# Altium schematic sheet Xberry 3 Memory and IO.SchDoc("Xberry 3 Memory and IO")
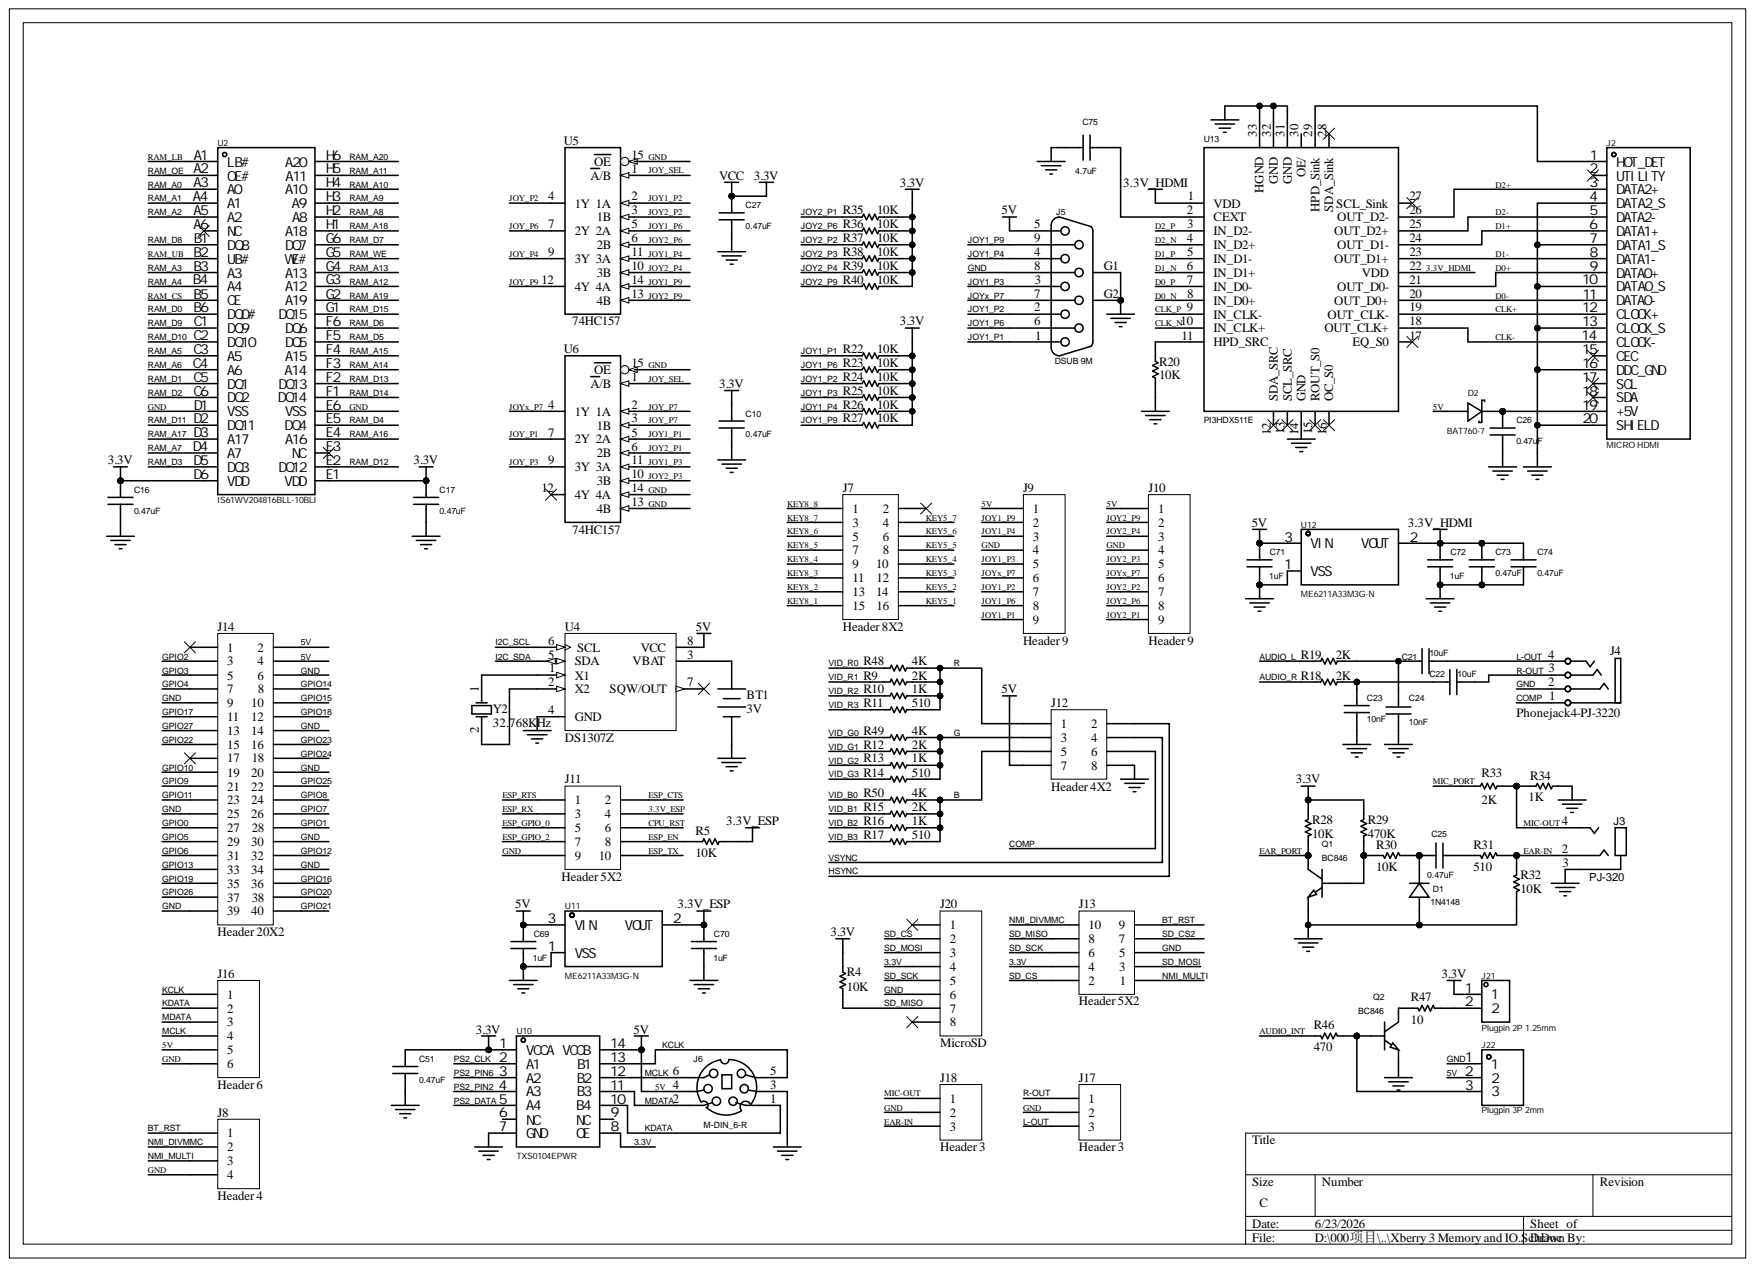
[diagram p0: Xberry 3 Memory and IO.SchDoc]

## Parts
BT1  3V  [BAT-TH_BS-12-A1AJ002-F]
C10  0.47uF  [CAP: 0402]
C16  0.47uF  [CAP: 0402]
C17  0.47uF  [CAP: 0402]
C21  10uF  [CAP: 0603]
C22  10uF  [CAP: 0603]
C23  10nF  [CAP: 0603]
C24  10nF  [CAP: 0603]
C25  0.47uF  [CAP: 0402]
C26  0.47uF  [CAP: 0402]
C27  0.47uF  [CAP: 0402]
C51  0.47uF  [CAP: 0402]
C69  1uF  [CAP: 0603]
C70  1uF  [CAP: 0603]
C71  1uF  [CAP: 0603]
C72  1uF  [CAP: 0603]
C73  0.47uF  [CAP: 0402]
C74  0.47uF  [CAP: 0402]
C75  4.7uF  [CAP: 0402]
D1  1N4148  [DIODE: SOD523]
D2  BAT760-7  [SOD-323_L1.8-W1.3-LS2.5-RD]
J2  MICRO HDMI  Connector Type: HDMI  [HDMI-MICRO_HDMI-101S]
J3  PJ-320  [AUDIO-TH_HOOYA_PJ-320]
J4  Phonejack4-PJ-3220  [AUDIO JACK PJ-3220-5A-C707251]
J5  DSUB 9M  [DB9_MALE]
J6  M-DIN_6-R  [DINC/MIN_TM/6]
J7  Header 8X2  [HDR2X8]
J8  Header 4  [HDR1X4]
J9  Header 9  [HDR1X9]
J10  Header 9  [HDR1X9]
J11  Header 5X2  [HDR2X5]
J12  Header 4X2  [HDR2X4]
J13  Header 5X2  [HDR2X5]
J14  Header 20X2  [HDR2X20]
J16  Header 6  [HDR1X6]
J17  Header 3  [HDR1X3]
J18  Header 3  [HDR1X3]
J20  MicroSD  [SD JLCPCB-C428492]
J21  Plugpin 2P 1.25mm  Number of Pins: 2P  Pitch: 1.25mm  [CONN-TH_0530470210]
J22  Plugpin 3P 2mm  Number of Pins: 3P  Pitch: 2mm  [CONN-TH_A2007WV-3P]
Q1  BC846  [SOT23]
Q2  BC846  [SOT23]
R4  10K  [RES: 0603]
R5  10K  [RES: 0603]
R9  2K  [RES: 0603]
R10  1K  [RES: 0603]
R11  510  [RES: 0603]
R12  2K  [RES: 0603]
R13  1K  [RES: 0603]
R14  510  [RES: 0603]
R15  2K  [RES: 0603]
R16  1K  [RES: 0603]
R17  510  [RES: 0603]
R18  2K  [RES: 0603]
R19  2K  [RES: 0603]
R20  10K  [RES: 0603]
R22  10K  [RES: 0603]
R23  10K  [RES: 0603]
R24  10K  [RES: 0603]
R25  10K  [RES: 0603]
R26  10K  [RES: 0603]
R27  10K  [RES: 0603]
R28  10K  [RES: 0603]
R29  470K  [RES: 0603]
R30  10K  [RES: 0603]
R31  510  [RES: 0603]
R32  10K  [RES: 0603]
R33  2K  [RES: 0603]
R34  1K  [RES: 0603]
R35  10K  [RES: 0603]
R36  10K  [RES: 0603]
R37  10K  [RES: 0603]
R38  10K  [RES: 0603]
R39  10K  [RES: 0603]
R40  10K  [RES: 0603]
R46  470  [RES: 0603]
R47  10  [RES: 0603]
R48  4K  [RES: 0603]
R49  4K  [RES: 0603]
R50  4K  [RES: 0603]
U2  IS61WV204816BLL-10BLI  [TFBGA-48_L8.0-W6.0-R8-C6-P0.75-TL]
U4  DS1307Z  [SOIC8N_N]
U5  74HC157  [SOP65P640-16M]
U6  74HC157  [SOP65P640-16M]
U10  TXS0104EPWR  [TSSOP-14_L5.0-W4.4-P0.65-LS6.4-BL]
U11  ME6211A33M3G-N  [SOT-23-3_L2.9-W1.6-P1.90-LS2.8-BR]
U12  ME6211A33M3G-N  [SOT-23-3_L2.9-W1.6-P1.90-LS2.8-BR]
U13  PI3HDX511E  [TQFN32_3X6]
Y2  32.768KHz  [CYL/D.150/LS.100/.031]

## Nets
3.3V: C10.1 C16.1 C17.1 C27.1 C51.1 J13.4 J20.4 J21.1 R4.2 R22.1 R23.1 R24.1 R25.1 R26.1 R27.1 R28.1 R29.1 R35.1 R36.1 R37.1 R38.1 R39.1 R40.1 U2.D6(VDD) U2.E1(VDD) U5.16 U6.16 U10.1(VCCA) U10.8(OE)
3.3V_ESP: C70.1 J11.4 R5.1 U11.2
3.3V_HDMI: C72.1 C73.1 C74.1 U12.2 U13.1(VDD) U13.22(VDD)
5V: C69.1 C71.1 D2.2 J5.5 J6.4 J9.1 J10.1 J12.7 J14.2 J14.4 J16.5 J22.2 U4.8(VCC) U10.14(VCCB) U11.3 U12.3
AUDIO_INT: R46.2
AUDIO_L: R19.1
AUDIO_R: R18.1
B: J12.5 R15.2 R16.2 R17.2 R50.2
BT_RST: J8.1 J13.9
CLK+: J2.12(CLOCK+) U13.19(OUT_CLK-)
CLK-: J2.14(CLOCK-) U13.18(OUT_CLK+)
CLK_N: U13.10(IN_CLK+)
CLK_P: U13.9(IN_CLK-)
COMP: J4.1 J12.6
CPU_RST: J11.6
D0+: J2.9(DATA0+) U13.21(OUT_D0-)
D0-: J2.11(DATA0-) U13.20(OUT_D0+)
D0_N: U13.8(IN_D0+)
D0_P: U13.7(IN_D0-)
D1+: J2.6(DATA1+) U13.24(OUT_D1-)
D1-: J2.8(DATA1-) U13.23(OUT_D1+)
D1_N: U13.6(IN_D1+)
D1_P: U13.5(IN_D1-)
D2+: J2.3(DATA2+) U13.26(OUT_D2-)
D2-: J2.5(DATA2-) U13.25(OUT_D2+)
D2_N: U13.4(IN_D2+)
D2_P: U13.3(IN_D2-)
EAR-IN: J3.2 J18.3 R31.1 R32.1
EAR_PORT: Q1.3 R28.2
ESP_CTS: J11.2
ESP_EN: J11.8 R5.2
ESP_GPIO_0: J11.5
ESP_GPIO_2: J11.7
ESP_RTS: J11.1
ESP_RX: J11.3
ESP_TX: J11.10
G: J12.3 R12.2 R13.2 R14.2 R49.2
GND: BT1.2 C10.2 C16.2 C17.2 C23.2 C24.1 C26.2 C27.2 C51.2 C69.2 C70.2 C71.2 C72.2 C73.2 C74.2 C75.2 D1.1 J2.4(DATA2_S) J2.7(DATA1_S) J2.10(DATA0_S) J2.13(CLOCK_S) J2.16(DDC_GND) J2.20(SHIELD) J3.3 J4.2 J5.8 J5.G1 J5.G2 J6.3 J6.M1 J6.M2 J6.M3 J8.4 J9.4 J10.4 J11.9 J12.8 J13.5 J14.6 J14.9 J14.14 J14.20 J14.25 J14.30 J14.34 J14.39 J16.6 J17.2 J18.2 J20.6 J22.1 Q1.2 Q2.2 R20.1 R32.2 R34.1 U2.D1(VSS) U2.E6(VSS) U4.4(GND) U5.8 U5.15(OE) U6.8 U6.13(4B) U6.14(4A) U6.15(OE) U10.7(GND) U11.1 U12.1 U13.14(GND) U13.31(GND) U13.32(GND) U13.33(HGND)
GPIO0: J14.27
GPIO1: J14.28
GPIO2: J14.3
GPIO3: J14.5
GPIO4: J14.7
GPIO5: J14.29
GPIO6: J14.31
GPIO7: J14.26
GPIO8: J14.24
GPIO9: J14.21
GPIO10: J14.19
GPIO11: J14.23
GPIO12: J14.32
GPIO13: J14.33
GPIO14: J14.8
GPIO15: J14.10
GPIO16: J14.36
GPIO17: J14.11
GPIO18: J14.12
GPIO19: J14.35
GPIO20: J14.38
GPIO21: J14.40
GPIO22: J14.15
GPIO23: J14.16
GPIO24: J14.18
GPIO25: J14.22
GPIO26: J14.37
GPIO27: J14.13
HSYNC: J12.2
I2C_SCL: U4.6(SCL)
I2C_SDA: U4.5(SDA)
JOY1_P1: J5.1 J9.9 R22.2 U6.5(2A)
JOY1_P2: J5.2 J9.7 R24.2 U5.2(1A)
JOY1_P3: J5.3 J9.5 R25.2 U6.11(3A)
JOY1_P4: J5.4 J9.3 R26.2 U5.11(3A)
JOY1_P6: J5.6 J9.8 R23.2 U5.5(2A)
JOY1_P9: J5.9 J9.2 R27.2 U5.14(4A)
JOY2_P1: J10.9 R35.2 U6.6(2B)
JOY2_P2: J10.7 R37.2 U5.3(1B)
JOY2_P3: J10.5 R38.2 U6.10(3B)
JOY2_P4: J10.3 R39.2 U5.10(3B)
JOY2_P6: J10.8 R36.2 U5.6(2B)
JOY2_P9: J10.2 R40.2 U5.13(4B)
JOY_P1: U6.7(2Y)
JOY_P2: U5.4(1Y)
JOY_P3: U6.9(3Y)
JOY_P4: U5.9(3Y)
JOY_P6: U5.7(2Y)
JOY_P7: U6.2(1A) U6.3(1B)
JOY_P9: U5.12(4Y)
JOY_SEL: U5.1(A/B) U6.1(A/B)
JOYx_P7: J5.7 J9.6 J10.6 U6.4(1Y)
KCLK: J6.5 J16.1 U10.13(B1)
KDATA: J6.1 J16.2 U10.10(B4)
KEY5_1: J7.16
KEY5_2: J7.14
KEY5_3: J7.12
KEY5_4: J7.10
KEY5_5: J7.8
KEY5_6: J7.6
KEY5_7: J7.4
KEY8_1: J7.15
KEY8_2: J7.13
KEY8_3: J7.11
KEY8_4: J7.9
KEY8_5: J7.7
KEY8_6: J7.5
KEY8_7: J7.3
KEY8_8: J7.1
L-OUT: C21.2 J4.4 J17.3
MCLK: J6.6 J16.4 U10.12(B2)
MDATA: J6.2 J16.3 U10.11(B3)
MIC-OUT: J3.4 J18.1 R33.2 R34.2
MIC_PORT: R33.1
NMI_DIVMMC: J8.2 J13.10
NMI_MULTI: J8.3 J13.1
PS2_CLK: U10.2(A1)
PS2_DATA: U10.5(A4)
PS2_PIN2: U10.4(A3)
PS2_PIN6: U10.3(A2)
R: J12.1 R9.2 R10.2 R11.2 R48.2
R-OUT: C22.2 J4.3 J17.1
RAM_A0: U2.A3(A0)
RAM_A1: U2.A4(A1)
RAM_A2: U2.A5(A2)
RAM_A3: U2.B3(A3)
RAM_A4: U2.B4(A4)
RAM_A5: U2.C3(A5)
RAM_A6: U2.C4(A6)
RAM_A7: U2.D4(A7)
RAM_A8: U2.H2(A8)
RAM_A9: U2.H3(A9)
RAM_A10: U2.H4(A10)
RAM_A11: U2.H5(A11)
RAM_A12: U2.G3(A12)
RAM_A13: U2.G4(A13)
RAM_A14: U2.F3(A14)
RAM_A15: U2.F4(A15)
RAM_A16: U2.E4(A16)
RAM_A17: U2.D3(A17)
RAM_A18: U2.H1(A18)
RAM_A19: U2.G2(A19)
RAM_A20: U2.H6(A20)
RAM_CS: U2.B5(CE)
RAM_D0: U2.B6(DQ0#)
RAM_D1: U2.C5(DQ1)
RAM_D2: U2.C6(DQ2)
RAM_D3: U2.D5(DQ3)
RAM_D4: U2.E5(DQ4)
RAM_D5: U2.F5(DQ5)
RAM_D6: U2.F6(DQ6)
RAM_D7: U2.G6(DQ7)
RAM_D8: U2.B1(DQ8)
RAM_D9: U2.C1(DQ9)
RAM_D10: U2.C2(DQ10)
RAM_D11: U2.D2(DQ11)
RAM_D12: U2.E2(DQ12)
RAM_D13: U2.F2(DQ13)
RAM_D14: U2.F1(DQ14)
RAM_D15: U2.G1(DQ15)
RAM_LB: U2.A1(LB#)
RAM_OE: U2.A2(OE#)
RAM_UB: U2.B2(UB#)
RAM_WE: U2.G5(WE#)
SD_CS: J13.2 J20.2
SD_CS2: J13.7
SD_MISO: J13.8 J20.7 R4.1
SD_MOSI: J13.3 J20.3
SD_SCK: J13.6 J20.5
VID_B0: R50.1
VID_B1: R15.1
VID_B2: R16.1
VID_B3: R17.1
VID_G0: R49.1
VID_G1: R12.1
VID_G2: R13.1
VID_G3: R14.1
VID_R0: R48.1
VID_R1: R9.1
VID_R2: R10.1
VID_R3: R11.1
VSYNC: J12.4
NetBT1_1: BT1.1 U4.3(VBAT)
NetC21_1: C21.1 C24.2 R19.2
NetC22_1: C22.1 C23.1 R18.2
NetC25_1: C25.1 D1.2 R30.1
NetC25_2: C25.2 R31.2
NetC26_1: C26.1 D2.1 J2.19(+5V)
NetC75_1: C75.1 U13.2(CEXT)
NetJ2_1: J2.1(HOT_DET) U13.29(HPD_Sink)
NetJ21_2: J21.2 R47.1
NetJ22_3: J22.3 Q2.1 R46.1
NetQ1_1: Q1.1 R29.2 R30.2
NetQ2_3: Q2.3 R47.2
NetR20_2: R20.2 U13.11(HPD_SRC)
NetU4_1: U4.1(X1) Y2.1
NetU4_2: U4.2(X2) Y2.2

Not connected: J2 2(UTILITY) 15(CEC) 17(SCL) 18(SDA); J7 2; J14 1 17; J20 1 8; U2 A6(NC) E3(NC); U4 7(SQW/OUT); U6 12(4Y); U10 6(NC) 9(NC); U13 12(SDA_SRC) 13(SCL_SRC) 15(ROUT_S0) 16(OC_S0) 17(EQ_S0) 27(SCL_Sink) 28(SDA_Sink) 30(OE/)

## Library data of the parts
BT1 = Battery: Multicell Battery; Component Kind: Standard; LatestRevisionDate: 17-Jul-2002; LatestRevisionNote: Re-released for DXP Platform.; Library Name: Miscellaneous Devices.IntLib; PackageDescription: Battery; 2 Leads; PackageReference: BAT-2; Published: 24-Mar-1999; Publisher: Altium Limited
C10 = CAP NP: COMPFIXED: NO; COMPGROUP: 0; COMPHEIGHT: 0.000; COMPLOC: [1695.000,1325.000]; COMPSIDE: BOT; FPLIST: SM/C_0603,SM/C_0805,SM/C_0603_VIA; COMPKEY: NO; COMPLOCKED: NO; Component Kind: Standard; COMPROT: 0; DECOUPLER: NO; MEMORY: NO
C16 C17 C26 C27 C51 C73-C75 = CAP NP: COMPFIXED: NO; COMPGROUP: 0; COMPHEIGHT: 0.000; COMPLOC: [1255.000,1345.000]; COMPROT: 90; COMPSIDE: BOT; FPLIST: SM/C_0805,SM/C_0603; COMPKEY: NO; COMPLOCKED: NO; Component Kind: Standard; DECOUPLER: NO; MEMORY: NO
C21 C22 = CAP NP: COMPFIXED: NO; COMPGROUP: 0; COMPHEIGHT: 0.000; COMPLOC: [250.000,620.000]; COMPROT: 180; COMPSIDE: TOP; FPLIST: SM/C_0805,SM/C_0603_VIA,SM/C_0603,SM/C_0805_VIA; COMPKEY: NO; COMPLOCKED: NO; Component Kind: Standard; DECOUPLER: NO; MEMORY: NO
C23-C25 = CAP NP: COMPFIXED: NO; COMPGROUP: 0; COMPHEIGHT: 0.000; COMPLOC: [1025.000,1280.000]; COMPSIDE: BOT; FPLIST: SM/C_0603_VIA,SM/C_0805,SM/C_0603; COMPKEY: NO; COMPLOCKED: NO; Component Kind: Standard; COMPROT: 0; DECOUPLER: NO; MEMORY: NO
  C25: Library Name: ZX_UNO_B.SchLib
  C23 C24: Library Name: Retro Computer.SchLib
C69-C72 = CAP NP: COMPFIXED: NO; COMPGROUP: 0; COMPHEIGHT: 0.000; COMPLOC: [1575.000,1660.000]; COMPROT: 180; COMPSIDE: BOT; FPLIST: SM/C_0805_VIA2,SM/C_0805,SM/C_0603_VIA,SM/C_0603,SM/C_0805_VIA; COMPKEY: NO; COMPLOCKED: NO; Component Kind: Standard; DECOUPLER: NO; MEMORY: NO
D1 = DIODE: COMPFIXED: NO; COMPGROUP: 0; COMPHEIGHT: 0.000; COMPLOC: [2505.000,640.000]; COMPROT: 180; COMPSIDE: BOT; COMPKEY: NO; COMPLOCKED: NO; Component Kind: Standard; DECOUPLER: NO; MEMORY: NO
D2 = BAT760-7: 1A 30V Schottky Diodes SOD-323; Manufacturer: DIODES(美台); Add into BOM: yes; Reverse Leakage Current (Ir): 50uA@15V; Voltage - Forward(Vf@If): 550mV@1A; Component Kind: Standard; Convert to PCB: yes; Current - Rectified: 1A; Datasheet: https://item.szlcsc.com/datasheet/BAT760-7/125462.html; Device: BAT760-7; JLCPCB Part Class: Extended Part; LCSC Part Name: 电压:30V 电流:1A; Library Name: JLCPCB.SchLib; Manufacturer Part: BAT760-7; PCB3D: SOD-323_L1.8-W1.3-LS2.5-RD; Supplier: LCSC; Supplier Footprint: SOD-323; Supplier Part: C124187; Symbol: BAT760-7; Voltage - DC Reverse(Vr): 30V
J2 = HDMI-101S: Manufacturer: XUNPU(讯普); Add into BOM: yes; Current Rating (Max): 500mA; Gender: Female; Component Kind: Standard; Contact Material: -; Contact Plating: -; Convert to PCB: yes; Datasheet: https://atta.szlcsc.com/upload/public/pdf/source/20221020/616DAE1EB19486B3E4252E4542EF441F.pdf; Device: HDMI-101S; JLCPCB Part Class: Extended Part; LCSC Part Name: HDMI 母 P数:19P; Manufacturer Part: HDMI-101S; Mounting Style: 卧贴; Number of Pins: 19P; Number of Rows: 2; Operating Temperature Range: -45℃~+85℃; PCB3D: HDMI-SMD_HDMI-101S; Supplier: LCSC; Supplier Footprint: SMD; Supplier Part: C720620; Symbol: HDMI-101S; Library Name: Retro Computer.SchLib
J3 = PJ-320: Manufacturer: HOOYA(皓宇电子); Contributor: LCSC; Component Kind: Standard; JLCPCB Part Class: Extended Part; Manufacturer Part: PJ-320; spicePre: C; spiceSymbolName: PJ-320; Supplier: LCSC; Supplier Part: C2939180; Library Name: Retro Computer.SchLib
J4 = Phonejack4-PJ-3220: Jack Socket, 3.5mm, Thru-Hole, Right Angle, 4-Conductor; DrillAndSlotSize: Corresponds to nominal value.; PackageDocument: 2003; PCB_Layout: Complies with manufacturer's recommendation.; Component Kind: Standard; ComponentLink1Description: Manufacturer Link; ComponentLink1URL: http://www.cliffuk.co.uk/; DatasheetDocument: 2003; PackageReference: S1VS_SBS; Published: 15-Jul-2004; Signal Integrity: JACK Socket; Library Name: Retro Computer.SchLib; Publisher: Altium Limited
J5 = CONNECTOR DB9: COMPFIXED: NO; COMPGROUP: 0; COMPHEIGHT: 0.000; COMPLOC: [425.000,1925.000]; COMPROT: 90; COMPSIDE: TOP; COMPKEY: NO; COMPLOCKED: NO; Component Kind: Standard; DECOUPLER: NO; MEMORY: NO; Library Name: Retro Computer.SchLib
J6 = M-DIN_6-R: COMPFIXED: YES; COMPGROUP: 0; COMPHEIGHT: 512.000; COMPKEY: NO; COMPLOC: [3385.533,373.983]; COMPROT: 270; COMPSIDE: TOP; COMPLOCKED: NO; Component Kind: Standard; DECOUPLER: NO; MEMORY: NO; Library Name: Retro Computer.SchLib
J7 = Header 8X2: Header, 8-Pin, Dual row; Component Kind: Standard; LatestRevisionDate: 17-Jul-2002; LatestRevisionNote: Re-released for DXP Platform.; Library Name: Miscellaneous Connectors.IntLib; Signal Integrity: Connector; Publisher: Altium Limited
J8 = Header 4: Header, 4-Pin; Component Kind: Standard; LatestRevisionDate: 17-Jul-2002; LatestRevisionNote: Re-released for DXP Platform.; Library Name: Miscellaneous Connectors.IntLib; Signal Integrity: Connector; Publisher: Altium Limited
J9 J10 = Header 9: Header, 9-Pin; Component Kind: Standard; LatestRevisionDate: 17-Jul-2002; LatestRevisionNote: Re-released for DXP Platform.; Library Name: Miscellaneous Connectors.IntLib; Signal Integrity: Connector; Publisher: Altium Limited
J11 J13 = Header 5X2: Header, 5-Pin, Dual row; Component Kind: Standard; LatestRevisionDate: 17-Jul-2002; LatestRevisionNote: Re-released for DXP Platform.; Library Name: Miscellaneous Connectors.IntLib; Signal Integrity: Connector; Publisher: Altium Limited
J12 = Header 4X2: Header, 4-Pin, Dual row; Component Kind: Standard; LatestRevisionDate: 17-Jul-2002; LatestRevisionNote: Re-released for DXP Platform.; Library Name: Miscellaneous Connectors.IntLib; Signal Integrity: Connector; Publisher: Altium Limited
J14 = Header 20X2: Header, 20-Pin, Dual row; Component Kind: Standard; LatestRevisionDate: 17-Jul-2002; LatestRevisionNote: Re-released for DXP Platform.; Library Name: Miscellaneous Connectors.IntLib; Signal Integrity: Connector; Publisher: Altium Limited
J16 = Header 6: Header, 6-Pin; Component Kind: Standard; LatestRevisionDate: 17-Jul-2002; LatestRevisionNote: Re-released for DXP Platform.; Library Name: Miscellaneous Connectors.IntLib; Signal Integrity: Connector; Publisher: Altium Limited
J17 J18 = Header 3: Header, 3-Pin; Component Kind: Standard; LatestRevisionDate: 17-Jul-2002; LatestRevisionNote: Re-released for DXP Platform.; Library Name: Miscellaneous Connectors.IntLib; Signal Integrity: Connector; Publisher: Altium Limited
J20 = Header 8: Header, 8-Pin; Component Kind: Standard; LatestRevisionDate: 17-Jul-2002; LatestRevisionNote: Re-released for DXP Platform.; Library Name: Miscellaneous Connectors.IntLib; Signal Integrity: Connector; Publisher: Altium Limited
J21 = 530470210-C114130: Plugin,P=1.25mm 2P Wire To Board Connector; Manufacturer: MOLEX; Add into BOM: yes; Current Rating (Max): 1A; Flame Retardant Rating: UL94V-0; Number of PINs Per Row: 2; Pins Structure: 1x2P; Plastic Material: PA; Rated Voltage (Max): 125V; Reference Series: PicoBlade(MX 1.25); Symbol: 0530470210; X-Length of Bottom Edge on Board (Spacing Line): 4.25mm; Y-Width of Bottom Edge on Board: 3.2mm; Z-Height of the Board: 4.2mm; Colour: White; Component Kind: Standard; Contact Material: Phosphor Bronze; Contact Plating: Tin; Convert to PCB: yes; Datasheet: https://www.molex.com/content/dam/molex/molex-dot-com/products/automated/en-us/salesdrawingpdf/530/53047/530470210_sd.pdf?inline; Device: 530470210; JLCPCB Part Class: Extended Part; LCSC Part Name: 1x2P 间距:1.25mm 直插 系列:PicoBlade(MX 1.25); Library Name: JLCPCB.SchLib; Manufacturer Part: 530470210; Mounting Style: Direct Insert; Number of Rows: 1; Operating Temperature Range: -40℃~+85℃; PCB3D: CONN-TH_MX1.25-LI-2P; Row Spacing: -; Supplier: LCSC; Supplier Footprint: 插件,P=1.25mm; Supplier Part: C114130
J22 = A2007WV-3P: Plugpin,P=2mm 3P Wire To Board Connector; Manufacturer: CJT(长江连接器); Add into BOM: yes; Current Rating: 4A; Flame Retardant Rating: UL94V-0; Number of PINs Per Row: 3; Pins Structure: 1x3P; Plastic Material: PA66; Voltage Rating: 125V; Color: -; Component Kind: Standard; Contact Material: Brass; Contact Plating: Tin; Convert to PCB: yes; Datasheet: https://item.szlcsc.com/datasheet/A2007WV-3P/225619.html; Device: A2007WV-3P; JLCPCB Part Class: Extended Part; LCSC Part Name: 1x3P 间距:2mm 直插; Library Name: JLCPCB.SchLib; Manufacturer Part: A2007WV-3P; Mounting Type: Through Hole; Number of Rows: 1; Operating Temperature: -40℃~+105℃; PCB3D: CONN-TH_A2007WV-3P; Reference Series: -; Row Spacing: -; Supplier: LCSC; Supplier Footprint: 插件,P=2mm; Supplier Part: C225336; Symbol: A2007WV-3P
Q1 Q2 = NPN: COMPFIXED: NO; COMPGROUP: 0; COMPHEIGHT: 0.000; COMPLOC: [2215.000,625.000]; COMPSIDE: BOT; FPLIST: SM/SOT23_123; COMPKEY: NO; COMPLOCKED: NO; Component Kind: Standard; COMPROT: 0; DECOUPLER: NO; MEMORY: NO; Library Name: Retro Computer.SchLib
R4 R5 R9-R20 R22-R40 R46-R50 = Res1: Resistor; Component Kind: Standard; LatestRevisionDate: 17-Jul-2002; LatestRevisionNote: Re-released for DXP Platform.; Library Name: Miscellaneous Devices.IntLib; PackageReference: AXIAL-0.3; Published: 8-Jun-2000; Signal Integrity: Res; Simulation: RESISTOR; Publisher: Altium Limited
  R4 R5 R20 R22-R28 R30 R32 R35-R40: Value: 10K
  R9 R12 R15 R18 R19 R33: Value: 2K
  R10 R13 R16 R34: Value: 1K
  R11 R14 R17 R31: Value: 510
  R29: Value: 470K
  R46: Value: 470
  R47: Value: 10
  R48-R50: Value: 4K
U2 = IS61WV204816BLL-10BLI: 2M x 16 HIGH-SPEED SRAM; Manufacturer: ISSI(美国芯成); Access Time: 8ns; Add into BOM: yes; Device: IS61WV102416FBLL-8BLI; Voltage - Supply: 2.4V~3.6V; Component Kind: Standard; Convert to PCB: yes; Datasheet: https://item.szlcsc.com/datasheet/IS61WV102416FBLL-8BLI/8179693.html; JLCPCB Part Class: Extended Part; LCSC Part Name: IS61WV102416FBLL-8BLI; Library Name: JLCPCB.SchLib; Manufacturer Part: IS61WV102416FBLL-8BLI; PCB3D: TFBGA-48_L8.0-W6.0-H1.1-R8-C6-P0.75-TL; Supplier: LCSC; Supplier Footprint: TFBGA-48(6x8); Supplier Part: C7211320; Symbol: IS61WV102416FBLL-8BLI
U4 = DS1307Z: 64 X 8 Serial Real-Time Clock; Code_IPC: SOIC127P600-8; Component Kind: Standard; ComponentLink1Description: Manufacturer Link; ComponentLink1URL: http://www.maxim-ic.com/; ComponentLink2Description: Datasheet; ComponentLink2URL: http://pdfserv.maxim-ic.com/en/ds/DS1307.pdf; ComponentLink3Description: Discontinuation Notice; ComponentLink3URL: http://www.maxim-ic.com/qa/pdfs/non-obsolescence-letter.pdf; DatasheetVersion: 10-Aug-1999; LatestRevisionDate: 13-Apr-2006; LatestRevisionNote: IPC-7351 Footprint Added.; Library Name: Dallas Peripheral Real Time Clock.IntLib; PackageDescription: 8-Pin Small Outline Integrated Circuit 1.27 mm Pitch; PackageReference: SOIC8N; PackageVersion: 1999; PCB3D: SOIC8N; Published: 13-Oct-2000; Publisher: Altium Limited
U5 U6 = 74HC157: IO_LEVEL: 0; PSpiceTemplate: X^@REFDES %G\ %1A %1B %2A %2B %3A %3B %4A %4B %A\/B %1Y %2Y %3Y %4Y %VCC %GND @MODEL PARAMS:\n+ IO_LEVEL=@IO_LEVEL MNTYMXDLY=@MNTYMXDLY; Component Kind: Standard; MNTYMXDLY: 0; Simulation: 74HC257; Library Name: Retro Computer.SchLib
U10 = TXS0104EPWR: Bidirectional Level Shifters; Manufacturer: TI(德州仪器); Add into BOM: yes; Data Rate: 24Mbps; Number of Circuits: 4; Output Type: Push-pull；Open Drain; Voltage - Supply(VCCA): 1.65V~3.6V; Voltage - Supply(VCCB): 2.3V~5.5V; Channel Type: Bidirectional; Component Kind: Standard; Convert to PCB: yes; Datasheet: https://www.ti.com/cn/lit/gpn/txs0104e; Device: TXS0104EPWR; Features: Automatic Direction Detection; JLCPCB Part Class: Extended Part; LCSC Part Name: TXS0104EPWR; Library Name: JLCPCB.SchLib; Manufacturer Part: TXS0104EPWR; Operating Temperature: -40℃~+85℃; PCB3D: TSSOP-14_L5.0-W4.4-H1.0-LS6.4-P0.65; Supplier: LCSC; Supplier Footprint: TSSOP-14; Supplier Part: C44955; Symbol: TXS0104EPWR
U11 U12 = ME6211A33M3G-N: 3.3V 500mA Positive SOT-23-3 Voltage Regulators; Manufacturer: MICRONE(南京微盟); Add into BOM: yes; Noise: 50uVrms; Number of Outputs: 1; Output Type: Fixed; Power Supply Rejection Ratio (PSRR): 70dB@(1kHz); standby current: 60uA; Voltage - Supply: 6V; Voltage Dropout: 120mV@(100mA); Component Kind: Standard; Convert to PCB: yes; Datasheet: https://atta.szlcsc.com/upload/public/pdf/source/20180224/C168807_15194366627921363679.pdf; Device: ME6211A33M3G-N; Features: Over Current Protection；Enable；Short Circuit Protection; JLCPCB Part Class: Extended Part; LCSC Part Name: 输入6V 输出3.3V 500mA; Library Name: JLCPCB.SchLib; Manufacturer Part: ME6211A33M3G-N; Operating Temperature: -40℃~+150℃; Output Configuration: Positive; Output Current: 500mA; Output Voltage: 3.3V; PCB3D: SOT-23-3L_L2.9-W1.6-H1.1-LS2.8-P0.95; Supplier: LCSC; Supplier Footprint: SOT-23-3; Supplier Part: C168807; Symbol: ME6211A33M3G-N
U13 = PI3HDX511EZLSEX: HDMI 1.4b Redriver; Component Kind: Standard; Library Name: JLCPCB.SchLib
Y2 = XTAL: Crystal Oscillator; Component Kind: Standard; LatestRevisionDate: 17-Jul-2002; LatestRevisionNote: Re-released for DXP Platform.; Library Name: Miscellaneous Devices.IntLib; PackageDescription: Crystal, Thru-Hole; 2 Leads; Body 3.1 x 8.2 mm (Dia.xH); PackageReference: R38; Published: 8-Jun-2000; Simulation: XTAL; Publisher: Altium Limited
